# Altium schematic sheet 12V dc-dc converter.SchDoc
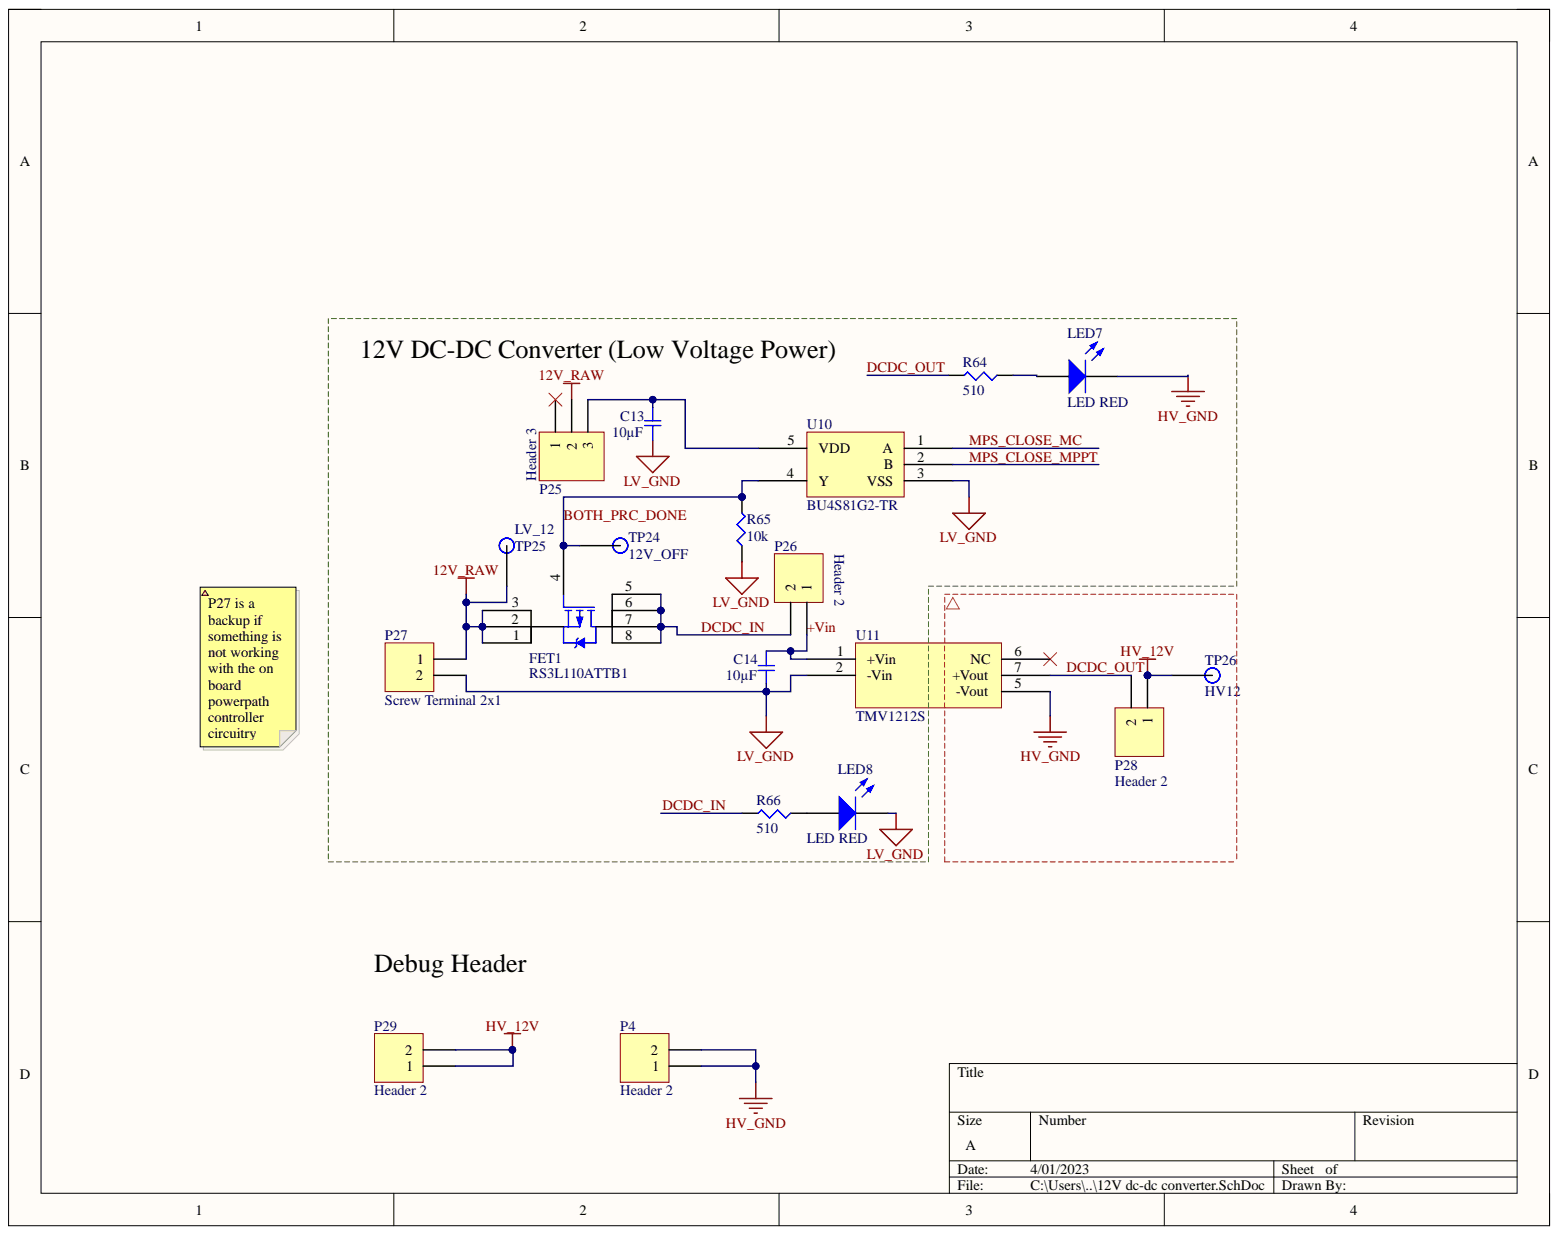
[diagram p0: 12V dc-dc converter.SchDoc]

## Parts
C13  value 10µF  [SMD-0805C]  (hidden comment: GCM21BR71A106KE22L)
C14  value 10µF  [SMD-0805C]  (hidden comment: GCM21BR71A106KE22L)
FET1  RS3L110ATTB1  [RS3L110AT]
LED7  LED RED  [LED 0603 ORANGE]
LED8  LED RED  [LED 0603 ORANGE]
P4  Header 2  [Pin Header 1x2 TH Pitch 2.54mm]
P25  Header 3  [Pin Header 1x3 TH Pitch 2.54mm]
P26  Header 2  [Pin Header 1x2 TH Pitch 2.54mm]
P27  Screw Terminal 2x1  [2POS TERM BLOCK]
P28  Header 2  [Pin Header 1x2 TH Pitch 2.54mm]
P29  Header 2  [Pin Header 1x2 TH Pitch 2.54mm]
R64  value 510  [SMD-0805-RES]  (hidden comment: ERJ-P06J511V)
R65  value 10k  [SMD-1206-RES]  (hidden comment: ERJ-8ENF1002V)
R66  value 510  [SMD-0805-RES]  (hidden comment: ERJ-P06J511V)
TP24  12V_OFF  [Test Point]
TP25  LV_12  [Test Point]
TP26  HV12  [Test Point]
U10  BU4S81G2-TR  [BU4S81G2]
U11  TMV1212S  [TMV1212S-Footprint-1]

## Nets
12V_RAW: FET1.1 FET1.2 FET1.3 P25.2 P27.1 TP25.1
HV_12V: P28.1 P29.1 P29.2 TP26.1
HV_GND: LED7.2 P4.1 P4.2 U11.5(-Vout)
LV_GND: C13.1 C14.2 LED8.2 P27.2 R65.1 U10.3(VSS) U11.2(-Vin)
+Vin: C14.1 P26.1 U11.1(+Vin)
BOTH_PRC_DONE: FET1.4 R65.2 TP24.1 U10.4(Y)
DCDC_IN: FET1.5 FET1.6 FET1.7 FET1.8 P26.2 R66.2
DCDC_OUT: P28.2 R64.2 U11.7(+Vout)
MPS_CLOSE_MC: U10.1(A)
MPS_CLOSE_MPPT: U10.2(B)
NetC13_2: C13.2 P25.3 U10.5(VDD)
NetLED7_1: LED7.1 R64.1
NetLED8_1: LED8.1 R66.1

Not connected: P25 1; U11 6(NC)

## Library data of the parts
C13 C14 = CMP-001-00205-1: CAP CER 10UF 10V X7R 0805; Manufacturer: Murata Electronics North America; @ qty: 0; Minimum Quantity: 1; Tolerance: 10%; Unit Price (USD): 0.49; Applications: Automotive; Component Kind: Standard; Datasheets: http://www.murata.com/~/media/webrenewal/support/library/catalog/products/capacitor/mlcc/c03e.ashx; Design Item ID: GCM21BR71A106KE22L; Digi-Key Part Number: 490-10674-1-ND; Factory Stock: 0; Features: -; Height - Seated (Max): -; Image: //media.digikey.com/photos/Murata%20Photos/NCP,GCM%20SERIES%200805.jpg; Lead Spacing: -; Lead Style: -; Library Name: Altium Concord Pro on A365; Mounting Type: Surface Mount, MLCC; Operating Temperature: -55°C ~ 125°C; Package / Case: 0805 (2012 Metric); Packaging: Cut Tape (CT); Part Number: GCM21BR71A106KE22L; Part Status: Active; Quantity Available: 125344; Ratings: AEC-Q200; Series: GCM; Source: capacitors.SchLib; Temperature Coefficient: X7R; Voltage: 10V
FET1 = CMP-008-000012-3: Transistor MOSFET P-Channel -60V ±11A 8-Pin SOP T/R; Transistor Type: P-channel MOSFET; Component Kind: Standard; Library Name: Altium Concord Pro on A365
LED7 LED8 = CMP-040-000018-1: A-0603UOC; Manufacturer: Lighthouse LEDs; Color: ORANGE; Minimum Quantity: 1; Availability: In Stock; Component Kind: Standard; ComponentLink1Description: Manufacturer; ComponentLink1URL: https://lighthouseleds.com/0603-smd-led-orange-ultra-bright-170-mcd.html; Datasheets: https://lighthouseleds.com/downloads/dl/file/id/11/0603_orange_amber_smd_led_datasheet.pdf; Design Item ID: LED ORANGE 0603; Library Name: Altium Concord Pro on A365; Manufacturer P/N: A-0603UOC; Package / Case: 0603; Source: misc.SchLib
P4 P26 P28 P29 = CMP-002-00072-1: Header, 2-Pin; Component Kind: Standard; Design Item ID: Header 2; LatestRevisionDate: 17-Jul-2002; LatestRevisionNote: Re-released for DXP Platform.; Library Name: Altium Concord Pro on A365; Source: connector.SchLib; Type: Connector; Publisher: Altium Limited
P25 = CMP-002-00073-1: Header, 3-Pin; Component Kind: Standard; Design Item ID: Header 3; LatestRevisionDate: 17-Jul-2002; LatestRevisionNote: Re-released for DXP Platform.; Library Name: Altium Concord Pro on A365; Source: connector.SchLib; Type: Connector; Publisher: Altium Limited
P27 = CMP-008-000000-1: Screw Terminal, 2-Pin; Component Kind: Standard; Design Item ID: Screw Terminal 2x1; Library Name: Altium Concord Pro on A365; Source: connector.SchLib; Type: Connector
R64 R66 = CMP-009-00645-1: RES SMD 510 OHM 5% 1/2W 0805; Manufacturer: Panasonic Electronic Components; @ qty: 1500; Factory Stock: 0; Minimum Quantity: 1; Power (Watts): 0.5W, 1/2W; Temperature Coefficient: ±200ppm/°C; Unit Price (USD): 0.01839; Component Kind: Standard; Composition: Thick Film; Datasheets: https://industrial.panasonic.com/cdbs/www-data/pdf/RDA0000/AOA0000C243.pdf; Design Item ID: ERJ-P06J511V; Digi-Key Part Number: P510ADCT-ND; Failure Rate: -; Features: Automotive AEC-Q200, Pulse Withstanding; Height - Seated (Max): 0.028" (0.70mm); Image: //media.digikey.com/Renders/Panasonic%20Renders/ERJ-0805%20Pkg.jpg; Library Name: Altium Concord Pro on A365; Number of Terminations: 2; Operating Temperature: -55°C ~ 155°C; Package / Case: 0805 (2012 Metric); Packaging: Cut Tape (CT); Part Number: ERJ-P06J511V; Part Status: Active; Quantity Available: 54515; Series: ERJ; Size / Dimension: 0.079" L x 0.049" W (2.00mm x 1.25mm); Source: resistors.SchLib; Supplier Device Package: 0805; Tolerance: 5%
R65 = CMP-009-01876-1: RES SMD 10K OHM 1% 1/4W 1206; Manufacturer: Panasonic Electronic Components; @ qty: 1500; Factory Stock: 0; Minimum Quantity: 1; Power (Watts): 0.25W, 1/4W; Temperature Coefficient: ±100ppm/°C; Unit Price (USD): 0.00933; Component Kind: Standard; Composition: Thick Film; Datasheets: http://industrial.panasonic.com/www-cgi/jvcr13pz.cgi?E+PZ+3+AOA0002+ERJ8ENF1002V+7+WW; Design Item ID: ERJ-8ENF1002V; Digi-Key Part Number: P10.0KFCT-ND; Failure Rate: -; Features: Automotive AEC-Q200; Height - Seated (Max): 0.028" (0.70mm); Image: //media.digikey.com/Renders/Panasonic%20Renders/ERJ-1206%20Pkg.jpg; Library Name: Altium Concord Pro on A365; Number of Terminations: 2; Operating Temperature: -55°C ~ 155°C; Package / Case: 1206 (3216 Metric); Packaging: Cut Tape (CT); Part Number: ERJ-8ENF1002V; Part Status: Active; Quantity Available: 1108398; Series: ERJ; Size / Dimension: 0.126" L x 0.063" W (3.20mm x 1.60mm); Source: resistors.SchLib; Supplier Device Package: 1206; Tolerance: 1%
TP24-TP26 = CMP-039-000000-1: SMD TEST POINT; Component Kind: Standard; Design Item ID: TP; Library Name: Altium Concord Pro on A365; Source: misc.SchLib
U10 = CMP-017-000001-1: AND Gate, 4000/14000/40000 Series, 1-Func, 2-Input, CMOS, PDSO5; Manufacturer: Rohm; Load Capacitance (CL): 50pF; Number of Functions: 1; Number of Inputs: 2; Package Body Material: Plastic; Package Equivalence Code: TSOP5/6,.11,37; Power Supplies: 3/16V; Qualification Status: Not Qualified; Schmitt Trigger: No; Supply Voltage-Max (Vsup): 16V; Supply Voltage-Min (Vsup): 3V; Supply Voltage-Nom (Vsup): 5V; Category: Logic Gates; Component Kind: Standard; ComponentLink1Description: https://datasheet.ciiva.com:443/pdfs/VipMasterIC/IC/ROHM/ROHM-S-A0001749750/ROHM-S-A0001749750-1.pdf?src-supplier=IHS+Markit; ComponentLink1URL: https://datasheet.ciiva.com:443/pdfs/VipMasterIC/IC/ROHM/ROHM-S-A0001749750/ROHM-S-A0001749750-1.pdf?src-supplier=IHS+Markit; ComponentLink2Description: https://datasheet.ciiva.com/12254/bu4s81g2-e-209681-12254411.pdf?src-supplier=Mouser; ComponentLink2URL: https://datasheet.ciiva.com/12254/bu4s81g2-e-209681-12254411.pdf?src-supplier=Mouser; ComponentLink3Description: https://datasheet.ciiva.com/1508/bu4s81g2-e-1508101.pdf?src-supplier=Element14; ComponentLink3URL: https://datasheet.ciiva.com/1508/bu4s81g2-e-1508101.pdf?src-supplier=Element14; ComponentLink4Description: https://datasheet.ciiva.com/1508/bu4s81g2-e-1508102.pdf?src-supplier=Element14; ComponentLink4URL: https://datasheet.ciiva.com/1508/bu4s81g2-e-1508102.pdf?src-supplier=Element14; ComponentLink5Description: https://datasheet.ciiva.com/8274/bu4s01g2-e-8274597.pdf?src-supplier=Avnet; ComponentLink5URL: https://datasheet.ciiva.com/8274/bu4s01g2-e-8274597.pdf?src-supplier=Avnet; ComponentLink6Description: https://datasheet.ciiva.com/6619/bu4s01g2-e-6619800.pdf?src-supplier=Digi-Key; ComponentLink6URL: https://datasheet.ciiva.com/6619/bu4s01g2-e-6619800.pdf?src-supplier=Digi-Key; ComponentLink7Description: https://datasheet.ciiva.com/6619/bu4s01g2-e-6619813.pdf?src-supplier=Digi-Key; ComponentLink7URL: https://datasheet.ciiva.com/6619/bu4s01g2-e-6619813.pdf?src-supplier=Digi-Key; ComponentLink8Description: https://datasheet.ciiva.com/6619/bu4s01g2-e-6619814.pdf?src-supplier=Digi-Key; ComponentLink8URL: https://datasheet.ciiva.com/6619/bu4s01g2-e-6619814.pdf?src-supplier=Digi-Key; ComponentLink9Description: https://datasheet.ciiva.com/16678/bu4s01g2-e-16678569.pdf?src-supplier=Avnet+Europe; ComponentLink9URL: https://datasheet.ciiva.com/16678/bu4s01g2-e-16678569.pdf?src-supplier=Avnet+Europe; Design Item ID: BU4S81G2-TR; Height - Seated (Max): 1.25mm; JESD-30 Code: R-PDSO-G5; Length: 2.9mm; Library Name: Altium Concord Pro on A365; Logic IC Type: AND GATE; Manufacturer Part Number: BU4S81G2-TR; Max Operating Temperature: 85°C; Min Operating Temperature: -40°C; Moisture Sensitivity Level: 1; Number of Pins: 5; Package Code: LSSOP; Package Shape: Rectangular; Package Style: SMALL OUTLINE, LOW PROFILE, SHRINK PITCHMeter; Packaging: Tape and Reel; Pricing 1: 1=0.90000, 10=0.79200, 25=0.74400, 100=0.60720, 250=0.56396, 500=0.47996, 1000=0.38397 (USD); REACH SVHC: Yes; RoHS: Yes; RoHS Compliant: Yes; Source: ic.SchLib; Stock 1: 2698; Surface Mount: Yes; Technology: CMOS; Temperature Grade: INDUSTRIAL; Terminal Form: Gull Wing; Terminal Pitch: 0.95mm; Terminal Position: DUAL; Width: 1.6mm
U11 = CMP-008-000009-3: DC-DC Regulated Power Supply Module, 1 Output, 1W, Hybrid; Component Kind: Standard; Library Name: Altium Concord Pro on A365
